# Altium schematic sheet AX5043_schema.SchDoc
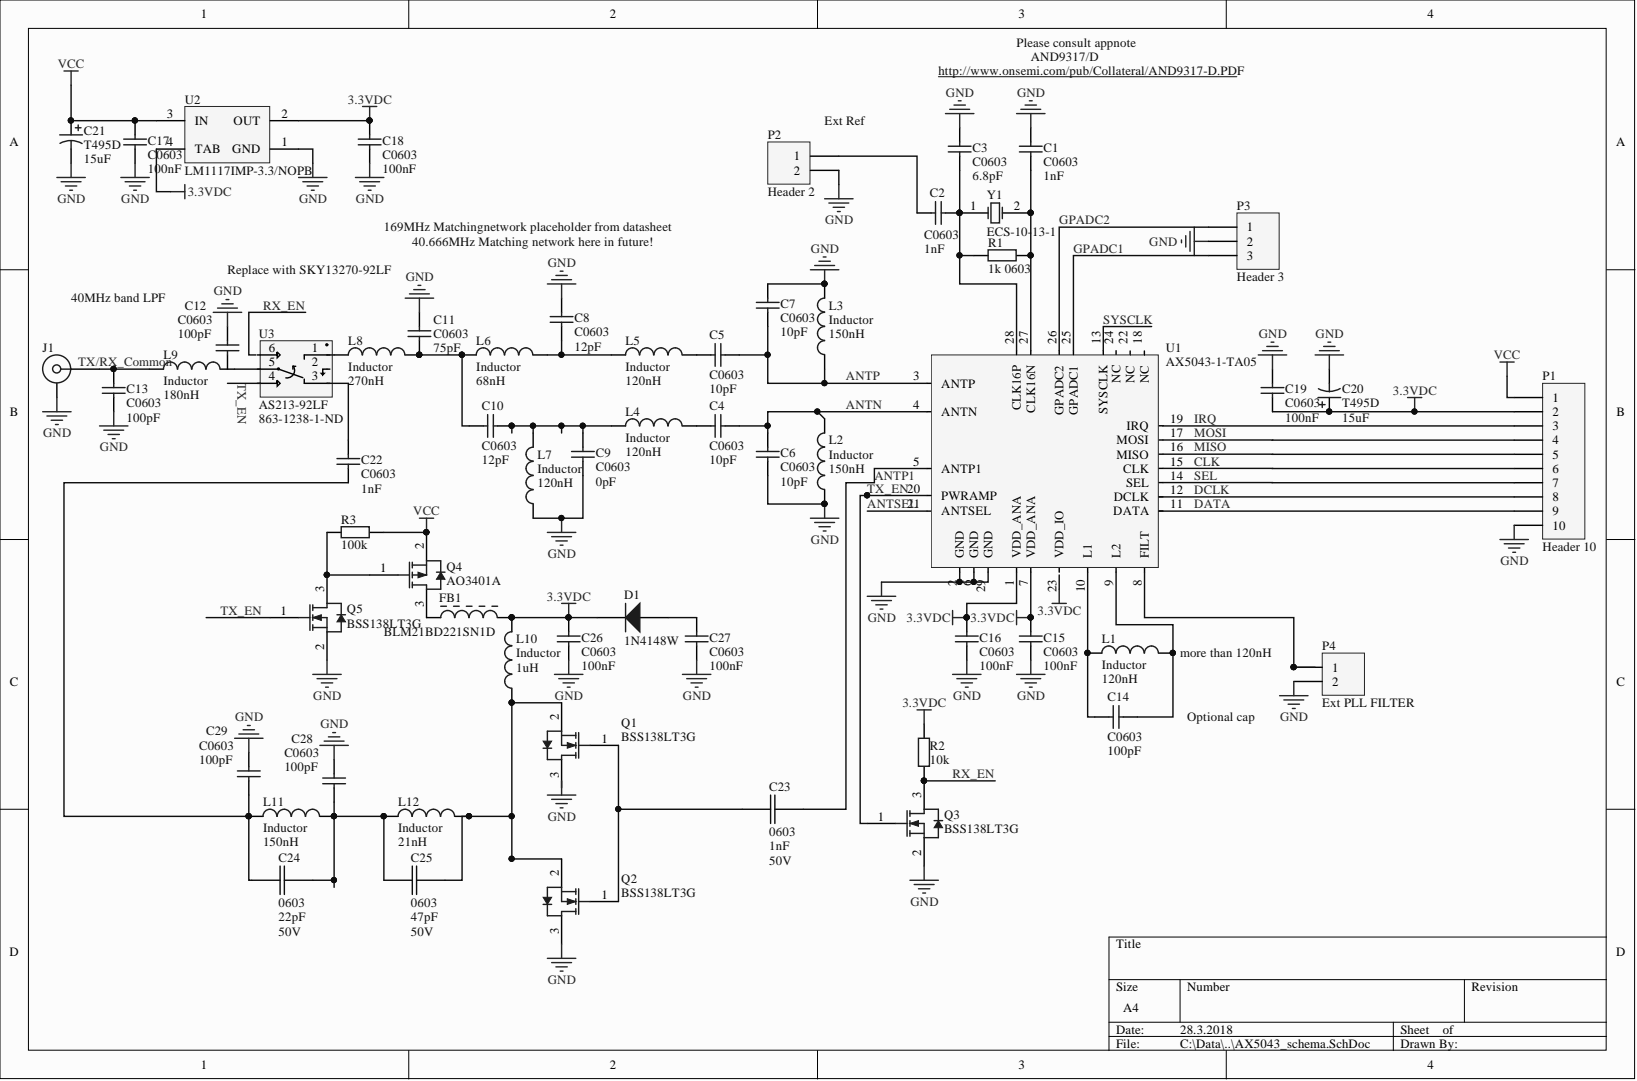
[diagram p0: AX5043_schema.SchDoc]

## Parts
C1  C0603  value 1nF  [0603]
C2  C0603  value 1nF  [0603]
C3  C0603  value 6.8pF  [0603]
C4  C0603  value 10pF  [0603]
C5  C0603  value 10pF  [0603]
C6  C0603  value 10pF  [0603]
C7  C0603  value 10pF  [0603]
C8  C0603  value 12pF  [0603]
C9  C0603  value 0pF  [0603]
C10  C0603  value 12pF  [0603]
C11  C0603  value 75pF  [0603]
C12  C0603  value 100pF  [0603]
C13  C0603  value 100pF  [0603]
C14  C0603  value 100pF  [0603]
C15  C0603  value 100nF  [0603]
C16  C0603  value 100nF  [0603]
C17  C0603  value 100nF  [0603]
C18  C0603  value 100nF  [0603]
C19  C0603  value 100nF  [0603]
C20  T495D  value 15uF  [D]
C21  T495D  value 15uF  [D]
C22  C0603  value 1nF  [0603]
C23  Capacitance: 1nF  Case/Package: 0603  [C0603_murata]  (hidden comment: 10nF 0603 NP0)
C24  Capacitance: 22pF  Case/Package: 0603  [C0603_murata]  (hidden comment: 10nF 0603 NP0)
C25  Capacitance: 47pF  Case/Package: 0603  [C0603_murata]  (hidden comment: 10nF 0603 NP0)
C26  C0603  value 100nF  [0603]
C27  C0603  value 100nF  [0603]
C28  C0603  value 100pF  [0603]
C29  C0603  value 100pF  [0603]
D1  1N4148W  [JSCJ-SOD-123-2_V]
FB1  BLM21BD221SN1D  [MURA-BLM21-H1-CHIP-2_V]
J1  32K145-400L5  [ROS-32K145-400L5_V]  (value not drawn)
L1  Inductor  value 120nH  [INDC1608L]
L2  Inductor  value 150nH  [INDC1608L]
L3  Inductor  value 150nH  [INDC1608L]
L4  Inductor  value 120nH  [INDC1608L]
L5  Inductor  value 120nH  [INDC1608L]
L6  Inductor  value 68nH  [INDC1608L]
L7  Inductor  value 120nH  [INDC1608L]
L8  Inductor  value 270nH  [INDC1608L]
L9  Inductor  value 180nH  [INDC1608L]
L10  Inductor  value 1uH  [INDC1608L]
L11  Inductor  value 150nH  [INDC1608L]
L12  Inductor  value 21nH  [INDC1608L]
P1  Header 10  [HDR1X10]
P2  Header 2  [HDR1X2]
P3  Header 3  [HDR1X3]
P4  Ext PLL FILTER  [HDR1X2]
Q1  BSS138LT3G  [ONSC-SOT-23-3-318-08_V]
Q2  BSS138LT3G  [ONSC-SOT-23-3-318-08_V]
Q3  BSS138LT3G  [ONSC-SOT-23-3-318-08_V]
Q4  AO3401A  [AO-SOT-23-3L_V]
Q5  BSS138LT3G  [ONSC-SOT-23-3-318-08_V]
R1  1k 0603  [R0603]
R2  10k  [R0603]
R3  100k  [R0603]
U1  AX5043-1-TA05  [QFN50P500X500X85_HS-29M]
U2  LM1117IMP-3.3/NOPB  [MP04A_N]
U3  AS213-92LF  [SC70_6]
Y1  ECS-10-13-1  [HC-49U]

## Nets
3.3VDC: C15.2 C16.2 C18.2 C19.1 C20.1 C26.1 D1.2 FB1.2 L10.2 P1.2 R2.2 U1.1(VDD_ANA) U1.7(VDD_ANA) U2.2(OUT) U2.4(TAB)
GND: C1.2 C3.2 C6.1 C7.2 C8.1 C9.2 C11.1 C12.1 C13.1 C15.1 C16.1 C17.1 C18.1 C19.2 C20.2 C21.2 C26.2 C27.2 C28.1 C29.1 J1.MP L2.1 L3.2 L7.1 P1.10 P2.2 P3.2 P4.2 Q2.3 Q3.2 Q5.2 U1.2(GND) U1.6(GND) U1.29(GND) U2.1(GND)
VCC: C17.2 C21.1 P1.1 Q4.2 R3.2 U2.3(IN)
ANTN: C4.2 C6.2 L2.2 U1.4(ANTN)
ANTP: C5.2 C7.1 L3.1 U1.3(ANTP)
ANTP1: C23.2 U1.5(ANTP1)
ANTSEL: U1.21(ANTSEL)
CLK: P1.6 U1.15(CLK)
DATA: P1.9
DCLK: P1.8
GPADC1: P3.3 U1.25(GPADC1)
GPADC2: P3.1 U1.26(GPADC2)
IRQ: P1.3 U1.19
MISO: P1.5 U1.16(MISO)
MOSI: P1.4 U1.17
RX_EN: Q3.3 R2.1
SEL: P1.7 U1.14(SEL)
SYSCLK: U1.13(SYSCLK)
TX_EN: Q3.1 Q5.1 U1.20(PWRAMP) U3.4
NetC1_1: C1.1 R1.2 U1.27(CLK16N) Y1.2
NetC2_1: C2.1 C3.1 R1.1 U1.28(CLK16P) Y1.1
NetC2_2: C2.2 P2.1
NetC4_1: C4.1 L4.2
NetC5_1: C5.1 L5.2
NetC8_2: C8.2 L5.1 L6.2
NetC9_1: C9.1 C10.2 L4.1 L7.2
NetC10_1: C10.1 C11.2 L6.1 L8.2
NetC13_2: C13.2 J1.1 L9.1
NetC14_1: C14.1 L1.1 U1.10(L1)
NetC14_2: C14.2 L1.2 U1.9(L2)
NetC22_1: C22.1 C24.1 C29.2 L11.1
NetC22_2: C22.2 U3.3
NetC23_1: C23.1 Q1.1 Q2.1
NetC24_2: C24.2 C25.1 C28.2 L11.2 L12.1
NetC25_2: C25.2 L10.1 L12.2 Q1.2 Q2.2
NetC27_1: C27.1 D1.1
NetFB1_1: FB1.1 Q4.3
NetL8_1: L8.1 U3.1
NetL9_2: L9.2 U3.5
NetP4_1: P4.1 U1.8
NetQ4_1: Q4.1 Q5.3 R3.1
TX/RX_Common:

Not connected: C12 2; Q1 3; U1 11 12 18(NC) 22(NC) 23(VDD_IO) 24(NC); U3 2 6

## Library data of the parts
C1-C4 C6-C19 C22 C26-C29 = C0603: Ceramic Chip Capacitor - Standard; Note: Footprint corresponds to dimensions for bulk cassette packaging. This case size has tightened tolerances.; Code_IPC: 1608 [0603]; Code_JEITA: 0603; Component Kind: Standard; ComponentLink1Description: Manufacturer Link; ComponentLink1URL: http://www.kemet.com/; ComponentLink2Description: Datasheet; ComponentLink2URL: http://www.kemet.com/kemet/web/homepage/kechome.nsf/vapubfilesname/F3102TA.pdf/$file/F3102TA.pdf; DatasheetDocument: Mar-2001; LatestRevisionDate: 19-Mar-2004; LatestRevisionNote: Silkscreen size reduced.; Library Name: KEMET Chip Capacitor.IntLib; PackageDocument: Mar-2001; PackageReference: 0603; Published: 17-Jul-2002; Signal Integrity: C0603; Simulation: CAP; Publisher: Altium Limited
C5 = C0603: Ceramic Chip Capacitor - Standard; Note: Footprint corresponds to dimensions for bulk cassette packaging. This case size has tightened tolerances.; Value: 10pF; Code_IPC: 1608 [0603]; Code_JEITA: 0603; Component Kind: Standard; ComponentLink1Description: Manufacturer Link; ComponentLink1URL: http://www.kemet.com/; ComponentLink2Description: Datasheet; ComponentLink2URL: http://www.kemet.com/kemet/web/homepage/kechome.nsf/vapubfilesname/F3102TA.pdf/$file/F3102TA.pdf; DatasheetDocument: Mar-2001; LatestRevisionDate: 19-Mar-2004; LatestRevisionNote: Silkscreen size reduced.; Library Name: KEMET Chip Capacitor.IntLib; PackageDocument: Mar-2001; PackageReference: 0603; Published: 17-Jul-2002; Signal Integrity: C0603; Simulation: CAP; Publisher: Altium Limited
C20 C21 = T495D: Solid Tantalum Chip Capacitor, Standard T495 Series - Low ESR, Surge Robust; Code_JEITA: 7343-31; Component Kind: Standard; ComponentLink1Description: Manufacturer Link; ComponentLink1URL: http://www.kemet.com/; ComponentLink2Description: Datasheet; ComponentLink2URL: http://www.kemet.com/kemet/web/homepage/kechome.nsf/vapubfilesname/F3102TA.pdf/$file/F3102TA.pdf; DatasheetDocument: Mar-2001; LatestRevisionDate: 19-Mar-2004; LatestRevisionNote: Silkscreen dimensions re-sized.; Library Name: KEMET Chip Capacitor.IntLib; PackageDocument: Mar-2001; PackageReference: D; Published: 17-Jul-2002; Signal Integrity: T495D; Simulation: CAP; Publisher: Altium Limited
C23-C25 = 10nF 0603 NP0: 10nF 0603 NP0 muRata; Manufacturer Part Number 1: GRM1885C1H103JA01D; Dielectric: C0G; Material: Ceramic; Tolerance: 5%; Voltage: 50V; Case Code (Imperial): 0603; Case Code (Metric): 1608; Component Kind: Standard; ComponentLink1Description: https://datasheet.ciiva.com/41860/partnumbering-e-01-41860759.pdf; ComponentLink1URL: https://datasheet.ciiva.com/41860/partnumbering-e-01-41860759.pdf; ComponentLink2Description: https://datasheet.ciiva.com/29537/partnumbering-e-01-29537910.pdf; ComponentLink2URL: https://datasheet.ciiva.com/29537/partnumbering-e-01-29537910.pdf; Depth: 0.8mm; Height: 0.8mm; Lead Free: Lead Free; Lead Pitch: 0.5mm; Length: 1.6mm; Manufacturer 1: Murata; Max Operating Temperature: 125°C; Min Operating Temperature: -55°C; Package Quantity: 4000; Packaging: Tape and Reel; Pricing 1: 1=0.13, 10=0.05, 50=0.05, 100=0.035, 1000=0.024, 10000=0.016 (USD); REACH SVHC: No SVHC; Stock 1: 5; Supplier 1: Mouser; Supplier Part Number 1: 81-GRM1885C1H103JA1D; Termination: SMD/SMT; Thickness: 0.035inch; Voltage Rating: 50V; Voltage Rating (DC): 50V; Width: 0.8mm; Library Name: ftg_lib.IntLib
D1 = CMP-0914-00001-1: Fast Switching Diode, 75 V, 150 mA, 2-Pin 2.7 x 1.6 x 1.25 mm SMD, Tape and Reel; Manufacturer: Changjiang Electronics; IO (mA): 150; IR (uA): 1; PD (mW): 350; Trr (ns): 4; TSTG (degC): -55 to 150; VF (V): 1.25; VR (V): 75; Component Kind: Standard; ComponentLink1Description: Manufacturer URL; ComponentLink1URL: http://www.cj-elec.com/; ComponentLink2Description: Datasheet; ComponentLink2URL: http://www.cj-elec.com/txUpfile/20121613352740313.pdf; ComponentLink3Description: Package Specification; ComponentLink3URL: http://www.cj-elec.com/txUpfile/201412112231195145.pdf; DatasheetVersion: Rev. A; Library Name: Altium Content Vault; Mounting Technology: Surface Mount; PackageDescription: 2-Pin SMD, Body 2.7 x 1.6 mm, Height 1.25 mm; PackageReference: SOD-123-2; PackageVersion: Rev. A; Packing: Tape and Reel; PartNumber: 1N4148W
FB1 = CMP-0686-00656-2: Chip Ferrite Bead for High Speed Signal lines, 220 Ohm, 200 mA, -55 to 125 degC, 2 x 1.25 x 1.05 mm SMD, Tape and Reel; Manufacturer: Murata; DC Resistance: 0.25 Ohm Max.; Impedance (at 100 MHz): 220 Ohm +/- 25%; Rated Current: 200 mA; Component Kind: Standard; ComponentLink1Description: Manufacturer URL; ComponentLink1URL: http://www.murata.com/; ComponentLink2Description: Datasheet; ComponentLink2URL: http://www.murata.com/products/catalog/pdf/c31e.pdf; ComponentLink3Description: Package Specification; ComponentLink3URL: http://www.murata.com/products/catalog/pdf/c31e.pdf; DatasheetVersion: 08/2013; Library Name: Altium Content Vault; Mounting Technology: Surface Mount; Operating Temperature Range: -55 to 125 degC; PackageDescription: 2-Pin Chip, Body 2 x 1.25 mm; PackageReference: BLM21_H1050-2; Packing: Tape and Reel; PartNumber: BLM21BD221SN1D
J1 = 32K145-400L5: SMA Right Angle Jack, Edge Mount, Up to 18 GHz, -55 to 155 degC; Desgin Note 2: For more information about DRC setting, please look at following webpage, http://techdocs.altium.com/display/SCRT/Design+Rule+for+Edge-Mount+Connectors; Design Note 1: Footprint in library is a generic example - only application specific footprint delivers High Performance; Component Kind: Standard; ComponentLink1Description: Manufacturer URL; ComponentLink1URL: http://www.rosenberger.de; ComponentLink2Description: Datasheet; ComponentLink2URL: http://rosenberger.de/ok/images/documents/db/32K145-400L5.pdf; ComponentLink3Description: Package Specification; ComponentLink3URL: http://rosenberger.de/ok/images/documents/db/32K145-400L5.pdf; ComponentLink4Description: Series Overview / Design Note; ComponentLink4URL: http://rosenberger.de/ok/images/documents/spez/32.pdf; ComponentLink5Description: Webshop / RFQ; ComponentLink5URL: http://www.rosenberger.com/en/contact/sales/; DatasheetVersion: b00; Mounting Technology: Surface Mount; PackageDescription: SMA Right Angle Jack, Edge Mount, 14.25 x 9.5 x 4.46 mm; PackageReference: 32K145-400L5; PackageVersion: b00; PartNumber: 32K145-400L5; Library Name: Rosenberger SMA.IntLib
L1 = Inductor: Code_IEC: 1005; Value: 120nH; Code_JEITA: 0402; Component Kind: Standard; Description: Inductor; LatestRevisionDate: 29-May-2009; LatestRevisionNote: IPC-7351 Footprint Added.; Library Name: Miscellaneous Devices.IntLib; PackageDescription: Chip Inductor; PackageReference: 0402-A; Published: 8-Jun-2000; Signal Integrity: Inductor; Simulation: INDUCTOR; Publisher: Altium Limited
L2-L5 L7-L10 = Inductor: Code_IEC: 1005; Code_JEITA: 0402; Component Kind: Standard; Description: Inductor; LatestRevisionDate: 29-May-2009; LatestRevisionNote: IPC-7351 Footprint Added.; Library Name: Miscellaneous Devices.IntLib; PackageDescription: Chip Inductor; PackageReference: 0402-A; Published: 8-Jun-2000; Signal Integrity: Inductor; Simulation: INDUCTOR; Publisher: Altium Limited
L6 = Inductor: Code_IEC: 1005; Value: 68nH; Code_JEITA: 0402; Component Kind: Standard; Description: Inductor; LatestRevisionDate: 29-May-2009; LatestRevisionNote: IPC-7351 Footprint Added.; Library Name: Miscellaneous Devices.IntLib; PackageDescription: Chip Inductor; PackageReference: 0402-A; Published: 8-Jun-2000; Signal Integrity: Inductor; Simulation: INDUCTOR; Publisher: Altium Limited
L11 = Inductor: Code_IEC: 1005; Value: 150nH; Code_JEITA: 0402; Component Kind: Standard; Description: Inductor; LatestRevisionDate: 29-May-2009; LatestRevisionNote: IPC-7351 Footprint Added.; Library Name: Miscellaneous Devices.IntLib; PackageDescription: Chip Inductor; PackageReference: 0402-A; Published: 8-Jun-2000; Signal Integrity: Inductor; Simulation: INDUCTOR; Publisher: Altium Limited
L12 = Inductor: Code_IEC: 1005; Value: 21nH; Code_JEITA: 0402; Component Kind: Standard; Description: Inductor; LatestRevisionDate: 29-May-2009; LatestRevisionNote: IPC-7351 Footprint Added.; Library Name: Miscellaneous Devices.IntLib; PackageDescription: Chip Inductor; PackageReference: 0402-A; Published: 8-Jun-2000; Signal Integrity: Inductor; Simulation: INDUCTOR; Publisher: Altium Limited
P1 = Header 10: Header, 10-Pin; Component Kind: Standard; LatestRevisionDate: 17-Jul-2002; LatestRevisionNote: Re-released for DXP Platform.; Library Name: Miscellaneous Connectors.IntLib; Signal Integrity: Connector; Publisher: Altium Limited
P2 P4 = Header 2: Header, 2-Pin; Component Kind: Standard; LatestRevisionDate: 17-Jul-2002; LatestRevisionNote: Re-released for DXP Platform.; Library Name: Miscellaneous Connectors.IntLib; Signal Integrity: Connector; Publisher: Altium Limited
P3 = Header 3: Header, 3-Pin; Component Kind: Standard; LatestRevisionDate: 17-Jul-2002; LatestRevisionNote: Re-released for DXP Platform.; Library Name: Miscellaneous Connectors.IntLib; Signal Integrity: Connector; Publisher: Altium Limited
Q1-Q3 Q5 = CMP-1058-00765-1: Power MOSFET, 200 mA, 50 V, N-Channel, 3-Pin SOT-23, Pb-Free, Tape and Reel; Manufacturer: On Semiconductor; Channel Polarity: N Channel; Ciss Typ (pF): 40; Configuration: Single; Coss Typ (pF): 12; Crss Typ (pF): 3.5; ID Max (A): 0.2; PD Max (W): 0.225; V(BR)DSS Min (V): 50; VGS(th) Max (V): 1.5; VGS Max (V): 20; Component Kind: Standard; ComponentLink1Description: Manufacturer URL; ComponentLink1URL: http://www.onsemi.com/; ComponentLink2Description: Datasheet; ComponentLink2URL: http://www.onsemi.com/pub_link/Collateral/BSS138LT1-D.PDF; ComponentLink3Description: Package Specification; ComponentLink3URL: http://www.onsemi.cn/pub_link/Collateral/318-08.PDF; DatasheetVersion: Rev. 8; Library Name: Altium Content Vault; Mounting Technology: Surface Mount; PackageDescription: 3-Pin Small Outline Transistor, Body 2.92 x 1.3 mm, Pitch 0.95 mm; PackageReference: SOT-23-3-318-08; Package Type: SOT-23-3; PackageVersion: Rev. AP, 11/2009; Packing: Tape and Reel; PartNumber: BSS138LT3G; RoHS: Pb-Free
Q4 = CMP-2000-05778-1: P-Channel MOSFET, 30 V, 4 A, -55 to 150 degC, 3-Pin SOT-23-3L, RoHS, Tape and Reel; Manufacturer: Alpha and Omega; Channel Mode: Enhancement; Continuous Drain Current: 4A; Drain-Source On-Res: 0.044ohm; Drain-Source On-Volt: 30V; Drain Efficiency: NotRequired%; Frequency (Max): NotRequiredMHz; Gate-Source Voltage (Max): ?12V; Noise Figure: NotRequireddB; Number of  Elements: 1; Output Power (Max): NotRequiredW; Polarity: P; Power Dissipation: 1.4W; Rad Hardened: No; Type: PowerMOSFET; Component Kind: Standard; Datasheet URL: http://www.aosmd.com/res/data_sheets/AO3401A.pdf; Datasheet Version: Rev. 3, 03/2011; Drain Current (Max): 4A; Library Name: Altium Content Vault; Manufacturer URL: http://www.aosmd.com/; Mounting: SurfaceMount; Mounting Technology: SurfaceMount; Operating Temperature Classification: Military; Operating Temp Range: -55Cto150C; Package Description: 3-Pin Small Outline Transistor, Body 2.95 x 1.6 mm, Pitch 0.95 mm; Package Reference: SOT-23-3L; Package Type: SOT-23; Package URL: http://www.aosmd.com/res/packaging_information/SOT-23-3L.pdf; Pin Count: 3; Power Gain: NotRequireddB
R2 R3 = 1k 0603: Component Kind: Standard; Library Name: ftg_lib.IntLib
U1 = AX5043: Data Tranceiver 27-1050MHz Separate TX/RX ports; Manufacturer: ON Semiconductor; Current - Receiving: 11mA; Current - Transmitting: 48mA; Data Rate: 125Kbps; Frequency: 27MHz; Interface: SPI,UART; Max Frequency: 1.05GHz; Max Supply Voltage: 3.6V; Min Supply Voltage: 1.8V; Sensitivity: -137dBm; Case/Package: QFN; Component Kind: Standard; ComponentLink1Description: http://www.mouser.com/ds/2/308/AX5043-D-782042.pdf; ComponentLink1URL: http://www.mouser.com/ds/2/308/AX5043-D-782042.pdf; ComponentLink2Description: http://www.onsemi.com/pub_link/Collateral/AX5043-D.PDF; ComponentLink2URL: http://www.onsemi.com/pub_link/Collateral/AX5043-D.PDF; ComponentLink3Description: http://www.farnell.com/datasheets/2009298.pdf; ComponentLink3URL: http://www.farnell.com/datasheets/2009298.pdf; ComponentLink4Description: http://www.onsemi.com/pub/Collateral/AX5043-D.PDF; ComponentLink4URL: http://www.onsemi.com/pub/Collateral/AX5043-D.PDF; Lead Free: Lead Free; Manufacturer Part Number: AX5043-1-TA05; Max Operating Temperature: 85°C; Min Operating Temperature: -40°C; Mount: Surface Mount; Number of Pins: 28; Packaging: Tape and Reel; REACH SVHC: No SVHC; Stock 1: 3949; Supplier 1: Mouser; Library Name: ftg_lib.IntLib
U2 = LM1117IMP-3.3/NOPB: 800mA Low-Dropout Linear Regulator, 4-pin SOT-223, Pb-Free; Manufacturer: National Semiconductor; CompType: LDO IC; High-Reliability: Y; PackageTopMarking: XYTT*N05B; PowerWise: N; Code_IPC: SOT223-4; Code_JEDEC: TO-261-AA; Component Kind: Standard; ComponentLink1Description: Manufacturer URL; ComponentLink1URL: http://www.national.com/; ComponentLink2Description: LM1117 Datasheet; ComponentLink2URL: http://www.national.com/ds/LM/LM1117.pdf; ComponentLink3Description: LM1117 Web Page; ComponentLink3URL: http://www.national.com/pf/LM/LM1117.html; ComponentLink4Description: MP04A Package Page; ComponentLink4URL: http://www.national.com/packaging/folders/mp04a.html; DatasheetVersion: Apr-2006; Library Name: National Semiconductor LDO.IntLib; PackageDescription: Molded SOT-223, 6.50 x 3.56 x 1.6mm Body, 4 Lead, 2.3mm Pitch; PackageReference: MP04A; PackageVersion: revB, Apr-2003; PartNumber: LM1117IMP-3.3/NOPB; PinCount: 4; RoHS: Y
U3 = AS213-92LF: Switch SPDT 0.1-3GHZ GAAS SC70-6; Manufacturer 1: Skyworks Solutions Inc.; Frequency - Lower: 100MHz; Frequency - Upper: 3GHz; IIP3: 40dBm (typ); Impedance: 50 Ohm; Insertion Loss @ Frequency: 0.5dB @ 3GHz; Isolation @ Frequency: 19dB @ 3GHz (typ); P1dB: 27dBm (typ) IP1dB; RF Type: Bluetooth, WLAN; Topology: Reflective; Voltage - Supply: 3V; Category: RF/IF and RFID; Circuit: SPDT; Component Kind: Standard; ComponentLink1Description: http://www.skyworksinc.com/uploads/documents/200193D.pdf; ComponentLink1URL: http://www.skyworksinc.com/uploads/documents/200193D.pdf; Features: -; Manufacturer Part Number 1: AS213-92LF; Operating Temperature: -40°C ~ 85°C; Package / Case: 6-TSSOP, SC-88, SOT-363; Packaging: Cut Tape (CT); Pricing 1: 1=0.41, 100=0.3393 (EUR); RoHS: RoHS Compliant; Stock 1: 26253; Supplier 1: Digi-Key; Supplier Device Package: SC-70-6; Supplier Part Number 1: 863-1238-1-ND; Library Name: ftg_lib.IntLib
Y1 = ECS-10-13-1: Crystal Oscillator; Component Kind: Standard; ComponentLink1Description: Manufacturer Link; ComponentLink1URL: http://www.ecsxtal.com/; ComponentLink2Description: Datasheet; ComponentLink2URL: http://www.ecsxtal.com/pdf2/HC49U.PDF; DatasheetDocument: 18-Apr-2000; Library Name: ECS Crystal Oscillator.IntLib; PackageReference: HC-49U; Published: 17-Jul-2002; Simulation: XTAL; Publisher: Altium Limited
R1 = 1k 0603: Component Kind: Standard; Library Name: ftg_lib.IntLib
